# Altium schematic sheet Power_Section.SchDoc
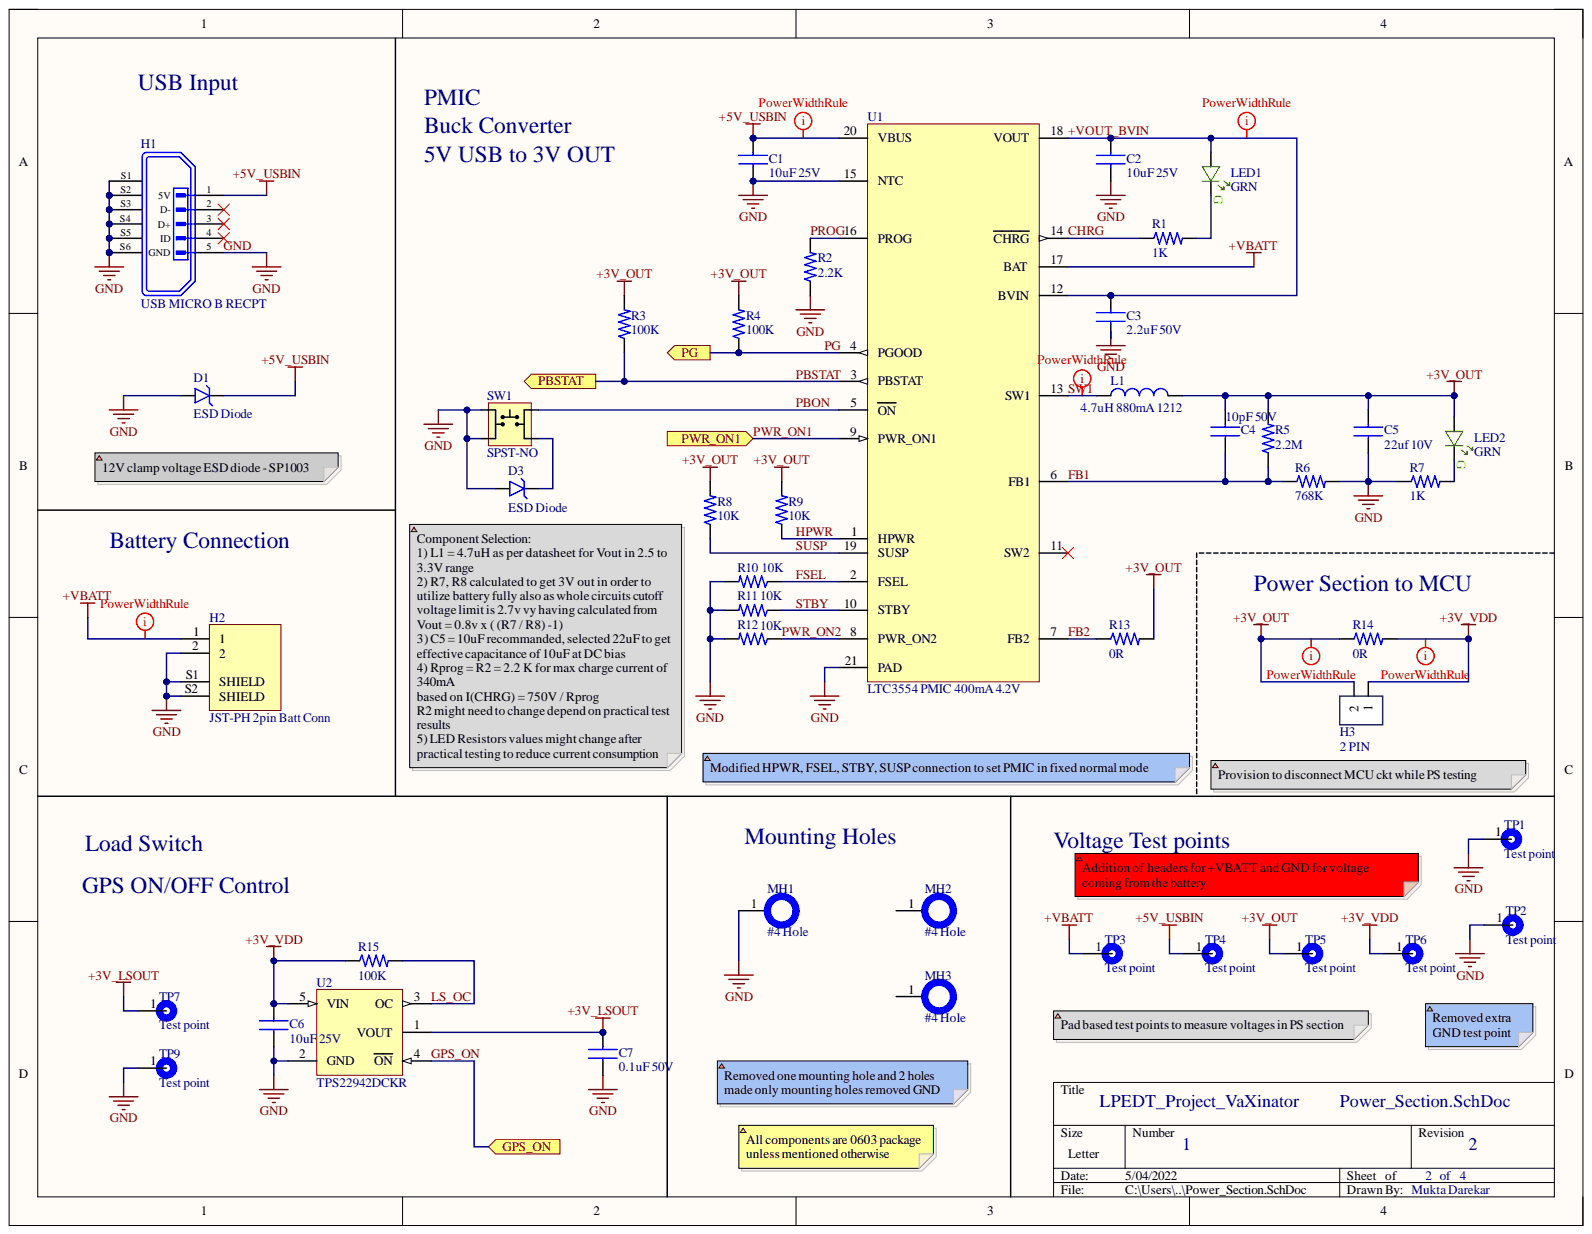
[diagram p0: Power_Section.SchDoc]

## Parts
C1  10uF 25V  [CAP_0603_1608X09N]
C2  10uF 25V  [CAP_0603_1608X09N]
C3  2.2uF 50V  Capacitance: 2.2uF  [CAP_0603_1608X09N]
C4  10pF 50V  [CAP_0603_1608X09N]
C5  22uf 10V  [CAP_0603_1608X09N]
C6  10uF 25V  [CAP_0603_1608X09N]
C7  0.1uF 50V  [CAP_0603_1608X09N]
D1  ESD Diode  [SOD882_DIODE_0402]
D3  ESD Diode  [SOD882_DIODE_0402]
H1  USB MICRO B RECPT  [MICROUSB_AMPHENOL_10118194-0001LF]
H2  JST-PH 2pin Batt Conn  [JST_S2B-PH-SM4-TB(LF)(SN)]
H3  2 PIN  [2_PIN_100MIL]
L1  4.7uH 880mA 1212  Current Rating: 880mA  Inductance: 4.7uH  [IND_CDRH2D11/HPNP-100NC]
LED1  GRN  [LED_0603_GRN_WL-SMCW]
LED2  GRN  [LED_0603_GRN_WL-SMCW]
MH1  #4 Hole  [HOLE_#4]  (no BOM)
MH2  #4 Hole  [HOLE_#4]  (no BOM)
MH3  #4 Hole  [HOLE_#4]  (no BOM)
R1  1K  [RES_0603_1608X06N]
R2  2.2K  [RES_0603_1608X06N]
R3  100K  [RES_0603_1608X06N]
R4  100K  [RES_0603_1608X06N]
R5  2.2M  Voltage Rating: 50V  [RES_0603_1608X06N]
R6  768K  [RES_0603_1608X06N]
R7  1K  [RES_0603_1608X06N]
R8  10K  [RES_0603_1608X06N]
R9  10K  [RES_0603_1608X06N]
R10  10K  [RES_0603_1608X06N]
R11  10K  [RES_0603_1608X06N]
R12  10K  [RES_0603_1608X06N]
R13  0R  [RES_0603_1608X06N]
R14  0R  [RES_0603_1608X06N]
R15  100K  [RES_0603_1608X06N]
SW1  SPST-NO  [TACT_SW_4PIN_SPST_450X450]
TP1  Test point  [TEST_POINT]  (no BOM)
TP2  Test point  [TEST_POINT]  (no BOM)
TP3  Test point  [TEST_POINT]  (no BOM)
TP4  Test point  [TEST_POINT]  (no BOM)
TP5  Test point  [TEST_POINT]  (no BOM)
TP6  Test point  [TEST_POINT]  (no BOM)
TP7  Test point  [TEST_POINT]  (no BOM)
TP9  Test point  [TEST_POINT]  (no BOM)
U1  LTC3554 PMIC 400mA 4.2V  [QFN20P300X300X80_HS-21N]
U2  TPS22942DCKR  [LS_SOT65P210X110-5N]

## Ports
GPS_ON, PBSTAT, PG, PWR_ON1

## Nets
+3V_LSOUT: C7.1 TP7.1 U2.1(VOUT)
+3V_OUT: C4.1 C5.1 H3.2 L1.2 LED2.A R3.2 R4.2 R5.2 R8.2 R9.2 R13.2 R14.1 TP5.1
+3V_VDD: C6.1 H3.1 R14.2 R15.1 TP6.1 U2.5(VIN)
+5V_USBIN: C1.1 D1.1 H1.1 TP4.1 U1.20(VBUS)
+VBATT: H2.1 TP3.1 U1.17(BAT)
+VOUT_BVIN: C2.1 C3.1 LED1.A U1.12(BVIN) U1.18(VOUT)
CHRG: R1.1 U1.14(CHRG)
FB1: C4.2 R5.1 R6.1 U1.6(FB1)
FB2: R13.1 U1.7(FB2)
FSEL: R10.1 U1.2(FSEL)
GND: C1.2 C2.2 C3.2 C5.2 C6.2 C7.2 D1.2 D3.2 H1.5 H1.S1 H1.S2(5V) H1.S3(D-) H1.S4(D+) H1.S5(ID) H1.S6(GND) H2.2 H2.S1(SHIELD) H2.S2(SHIELD) MH1.1 R2.1 R6.2 R7.1 R10.2 R11.2 R12.2 SW1.1 SW1.4 TP1.1 TP2.1 TP9.1 U1.15(NTC) U1.21(PAD) U2.2(GND)
GPS_ON: U2.4(ON) ; port GPS_ON
HPWR: R9.1 U1.1(HPWR)
LS_OC: R15.2 U2.3(OC)
PBON: SW1.2 U1.5(ON)
PBSTAT: R3.1 U1.3(PBSTAT) ; port PBSTAT
PG: R4.1 U1.4(PGOOD) ; port PG
PROG: R2.2 U1.16(PROG)
PWR_ON1: U1.9(PWR_ON1) ; port PWR_ON1
PWR_ON2: R12.1 U1.8(PWR_ON2)
STBY: R11.1 U1.10(STBY)
SUSP: R8.1 U1.19(SUSP)
SW1: L1.1 U1.13(SW1)
NetD3_1: D3.1 SW1.3
NetLED1_C: LED1.C R1.2
NetLED2_C: LED2.C R7.2

Not connected: H1 2 3 4; MH2 1; MH3 1; U1 11(SW2)

## Library data of the parts
C1 C2 C6 = GRM188R61E106KA73D: CAP CER 10UF 25V X5R 0603 MURATA; Case/Package: 0603; Category: Ceramic Capacitors; Component Kind: Standard; ComponentLink1Description: https://search.murata.co.jp/Ceramy/image/img/A01X/G101/ENG/GRM188R61E106KA73-01.pdf?src-supplier=Digi-Key; ComponentLink1URL: https://search.murata.co.jp/Ceramy/image/img/A01X/G101/ENG/GRM188R61E106KA73-01.pdf?src-supplier=Digi-Key; Library Name: LPEDT_Project_lib.IntLib; Manufacturer: Murata; Manufacturer Part Number: GRM188R61E106KA73D; Pricing 1: 1=0.32000, 10=0.22500, 50=0.15740, 100=0.13500, 500=0.10122, 1000=0.08548 (USD); RoHS: Yes; RoHS Compliant: Yes; Stock 1: 517064; Supplier 1: Digi-Key
C3 = GRM188R61H225KE11D: CAP CER 2.2 uF 50 V X5R 0603 ±10% Tolerance; Manufacturer: Murata; Material: Ceramic; Case Code (Imperial): 0603; Case Code (Metric): 1608; Case/Package: 0603; Category: Ceramic Capacitors; Component Kind: Standard; ComponentLink1Description: http://www.farnell.com/datasheets/2079169.pdf?src-supplier=Farnell; ComponentLink1URL: http://www.farnell.com/datasheets/2079169.pdf?src-supplier=Farnell; ComponentLink2Description: http://www.farnell.com/datasheets/2079169.pdf?src-supplier=Element14; ComponentLink2URL: http://www.farnell.com/datasheets/2079169.pdf?src-supplier=Element14; ComponentLink3Description: http://search.murata.co.jp/Ceramy/image/img/A01X/G101/ENG/GRM188R61H225KE11-01.pdf?src-supplier=Digi-Key; ComponentLink3URL: http://search.murata.co.jp/Ceramy/image/img/A01X/G101/ENG/GRM188R61H225KE11-01.pdf?src-supplier=Digi-Key; Dielectric: X5R; Height: 0.8mm; Length: 0.063inch; Library Name: LPEDT_Project.SchLib; Manufacturer Part Number: GRM188R61H225KE11D; Max Operating Temperature: 85°C; Min Operating Temperature: -55°C; Mount: Surface Mount; Packaging: Cut Tape; Pricing 1: 1=0.35000, 10=0.23800, 50=0.15840, 100=0.13460, 500=0.09504, 1000=0.08316 (USD); REACH SVHC: No SVHC; RoHS: Yes; RoHS Compliant: Yes; Supplier 1: Digi-Key; Thickness: 0.039inch; Tolerance: 10%; Voltage Rating: 50V; Voltage Rating (DC): 50V; Width: 0.031inch
C4 = C0603C100J5RAC7867: CAP CER 10pF 50V X7R 0603 KEMET; Category: Ceramic Capacitors; Component Kind: Standard; Library Name: LPEDT_Project.SchLib; Manufacturer: KEMET; Manufacturer Part Number: C0603C100J5RAC7867; Pricing 1: 1=0.25000, 10=0.17400, 50=0.11580, 100=0.09840, 500=0.06944, 1000=0.06075 (USD); RoHS: Yes; RoHS Compliant: Yes; Supplier 1: Digi-Key
C5 = GRM187R61A226ME15D: CAP CER 22 uF 10 V X5R 0603 ±20% Tolerance Multilayer Ceramic Capacitor; Manufacturer: Murata; Capacitance: 22uF; Case Code (Imperial): 0603; Case Code (Metric): 1608; Case/Package: 0603; Category: Ceramic Capacitors; Component Kind: Standard; ComponentLink1Description: http://search.murata.co.jp/Ceramy/image/img/A01X/G101/ENG/GRM187R61A226ME15-01.pdf?src-supplier=Digi-Key; ComponentLink1URL: http://search.murata.co.jp/Ceramy/image/img/A01X/G101/ENG/GRM187R61A226ME15-01.pdf?src-supplier=Digi-Key; Dielectric: X5R; Length: 0.063inch; Library Name: LPEDT_Project_lib.IntLib; Manufacturer Part Number: GRM187R61A226ME15D; Max Operating Temperature: 85°C; Min Operating Temperature: -55°C; Mount: Surface Mount; Packaging: Tape and Reel; Pricing 1: 1=0.52000, 10=0.36500, 50=0.27580, 100=0.24030, 500=0.17798, 1000=0.15128 (USD); RoHS: Yes; RoHS Compliant: Yes; Stock 1: 886277; Supplier 1: Digi-Key; Thickness: 0.031inch; Tolerance: 20%; Voltage Rating: 10V; Voltage Rating (DC): 10V; Width: 0.031inch
C7 = C0603C104M5RACTU: CAP CER 0.1UF 50V X7R 0603 KEMET; Capacitance: 100nF; Dielectric Material: Ceramic; Dissipation Factor: 2.5%; Tolerance: 20%; Case Code (Imperial): 0603; Case Code (Metric): 1608; Case/Package: 0603; Category: Ceramic Capacitors; Component Kind: Standard; ComponentLink1Description: http://components.arrow.com/part/dataview/40911037S8853915N7429?src-supplier=Arrow+Electronics; ComponentLink1URL: http://components.arrow.com/part/dataview/40911037S8853915N7429?src-supplier=Arrow+Electronics; ComponentLink2Description: https://www.verical.com/server-webapp/html/getDatasheet?partID=626892&src-supplier=Verical; ComponentLink2URL: https://www.verical.com/server-webapp/html/getDatasheet?partID=626892&src-supplier=Verical; ComponentLink3Description: http://www.farnell.com/datasheets/2746056.pdf?src-supplier=Farnell; ComponentLink3URL: http://www.farnell.com/datasheets/2746056.pdf?src-supplier=Farnell; ComponentLink4Description: http://components.arrow.com/part/dataview/0323_00313986?src-supplier=Arrow+Europe; ComponentLink4URL: http://components.arrow.com/part/dataview/0323_00313986?src-supplier=Arrow+Europe; ComponentLink5Description: http://www.mouser.com/ds/2/212/KEM_C1002_X7R_SMD-1102033.pdf?src-supplier=Mouser; ComponentLink5URL: http://www.mouser.com/ds/2/212/KEM_C1002_X7R_SMD-1102033.pdf?src-supplier=Mouser; ComponentLink6Description: http://www.alliedelec.com/m/d/6f3b5eb83d09db50ed28bdc730567b33.pdf?src-supplier=Allied+Electronics; ComponentLink6URL: http://www.alliedelec.com/m/d/6f3b5eb83d09db50ed28bdc730567b33.pdf?src-supplier=Allied+Electronics; ComponentLink7Description: http://www.farnell.com/datasheets/1828800.pdf?src-supplier=Newark; ComponentLink7URL: http://www.farnell.com/datasheets/1828800.pdf?src-supplier=Newark; ComponentLink8Description: http://capacitoredge.kemet.com/capedge2/DataSheet?pn=C0603C104M5RAC7867&src-supplier=Avnet; ComponentLink8URL: http://capacitoredge.kemet.com/capedge2/DataSheet?pn=C0603C104M5RAC7867&src-supplier=Avnet; ComponentLink9Description: http://www.farnell.com/datasheets/2315113.pdf?src-supplier=Newark; ComponentLink9URL: http://www.farnell.com/datasheets/2315113.pdf?src-supplier=Newark; ComponentLink10Description: https://api.kemet.com/component-edge/download/datasheet/C0603C104M5RACTU.pdf?src-supplier=Digi-Key; ComponentLink10URL: https://api.kemet.com/component-edge/download/datasheet/C0603C104M5RACTU.pdf?src-supplier=Digi-Key; ComponentLink11Description: https://www.verical.com/server-webapp/html/getDatasheet?partID=110138&src-supplier=Verical; ComponentLink11URL: https://www.verical.com/server-webapp/html/getDatasheet?partID=110138&src-supplier=Verical; ComponentLink12Description: http://www.farnell.com/datasheets/2746056.pdf?src-supplier=Element14; ComponentLink12URL: http://www.farnell.com/datasheets/2746056.pdf?src-supplier=Element14; ComponentLink13Description: http://www.farnell.com/datasheets/2746056.pdf?src-supplier=Newark; ComponentLink13URL: http://www.farnell.com/datasheets/2746056.pdf?src-supplier=Newark; ComponentLink14Description: http://capacitoredge.kemet.com/capedge2/DataSheet?pn=C0603C104M5RAC7867&src-supplier=Avnet+Asia; ComponentLink14URL: http://capacitoredge.kemet.com/capedge2/DataSheet?pn=C0603C104M5RAC7867&src-supplier=Avnet+Asia; Construction: Flat; Contact Plating: Tin,Matte; Depth: 0.8mm; Dielectric: X7R; Height: 0.8mm; Lead Pitch: 0.7mm; Length: 1.6mm; Library Name: LPEDT_Project_lib.IntLib; Manufacturer: KEMET; Manufacturer Part Number: C0603C104M5RACTU; Max Operating Temperature: 125°C; Min Operating Temperature: -55°C; Mount: Surface Mount,PCB; Package Quantity: 1; Packaging: Tape and Reel; Pricing 1: 1=0.10000, 10=0.03400, 50=0.01840, 100=0.01510, 500=0.01078, 1000=0.00847 (USD); Radiation Hardening: No; REACH SVHC: No SVHC; RoHS: Yes; Series: C; Supplier 1: Digi-Key; Termination: SMD/SMT; Thickness: 0.034inch; Voltage Rating: 50V; Voltage Rating (DC): 50V; Width: 0.031inch
D1 D3 = SP1003-01ETG: TVS DIODE 5VWM 12VC SOD882; Manufacturer: Littelfuse; Breakdown Voltage: 5V; Capacitance: 30pF; Clamping Voltage: 12V; Diode Type: ZENER; Leakage Current: 100nA; Max Surge Current: 7A; Min Breakdown Voltage: 6V; Number of Channels: 1; Polarity: Bidirectional,Unidirectional; Power Line Protection: No; Test Current: 1mA; Category: TVS Diodes; Component Kind: Standard; ComponentLink1Description: https://datasheet.ciiva.com/4507/littelfuse-tvs-diode-array-spa-sp1003-221268-4507734.pdf?src-supplier=Mouser; ComponentLink1URL: https://datasheet.ciiva.com/4507/littelfuse-tvs-diode-array-spa-sp1003-221268-4507734.pdf?src-supplier=Mouser; ComponentLink2Description: https://datasheet.ciiva.com/16488/littelfuse-tvs-diode-array-spa-sp1003-16488471.pdf?src-supplier=Future+Electronics; ComponentLink2URL: https://datasheet.ciiva.com/16488/littelfuse-tvs-diode-array-spa-sp1003-16488471.pdf?src-supplier=Future+Electronics; ComponentLink3Description: https://datasheet.ciiva.com/1268/1854133-1268499.pdf?src-supplier=Element14; ComponentLink3URL: https://datasheet.ciiva.com/1268/1854133-1268499.pdf?src-supplier=Element14; ComponentLink4Description: https://datasheet.ciiva.com/330/littelfuse-tvs-diode-array-sp1003-datasheet-pdf-330595.pdf?src-supplier=Digi-Key; ComponentLink4URL: https://datasheet.ciiva.com/330/littelfuse-tvs-diode-array-sp1003-datasheet-pdf-330595.pdf?src-supplier=Digi-Key; ComponentLink5Description: https://datasheet.ciiva.com/330/littelfuse-tvs-diode-array-sp1003-datasheet-pdf-330596.pdf?src-supplier=Digi-Key; ComponentLink5URL: https://datasheet.ciiva.com/330/littelfuse-tvs-diode-array-sp1003-datasheet-pdf-330596.pdf?src-supplier=Digi-Key; ComponentLink6Description: https://datasheet.ciiva.com/330/littelfuse-tvs-diode-array-sp1003-datasheet-pdf-330594.pdf?src-supplier=Digi-Key; ComponentLink6URL: https://datasheet.ciiva.com/330/littelfuse-tvs-diode-array-sp1003-datasheet-pdf-330594.pdf?src-supplier=Digi-Key; Lead Free: Lead Free; Library Name: LPEDT_Project_lib.IntLib; Manufacturer Part Number: SP1003-01ETG; Max Operating Temperature: 85°C; Min Operating Temperature: -40°C; Mount: Surface Mount; Number of Elements: 1; Number of Pins: 2; Number of Unidirectional Channels: 1; Operating Supply Voltage: 5V; Package Quantity: 3000; Packaging: Tape and Reel; Peak Pulse Current: 7A; Pricing 1: 1=0.47000, 10=0.38000, 100=0.25870, 500=0.19404, 1000=0.14553, 2000=0.13340, 5000=0.12532 (USD); REACH SVHC: No SVHC; Resistor Type: Zener; Reverse Breakdown Voltage: 6V; Reverse Standoff Voltage: 5V; RoHS: Yes; RoHS Compliant: Yes; Series: Automotive, AEC-Q101, SP1003, SPA®; Stock 1: 77390; Supplier 1: Digi-Key; Termination: SMD/SMT
H1 = 10118194-0001LF: USB - micro B USB 2.0 Receptacle Connector 5 Position Surface Mount, Right Angle; Through Hole; Manufacturer: Amphenol ICC / FCI; Category: USB Connectors; Component Kind: Standard; ComponentLink1Description: http://components.arrow.com/part/dataview/0323_04107373?src-supplier=Arrow+Europe; ComponentLink1URL: http://components.arrow.com/part/dataview/0323_04107373?src-supplier=Arrow+Europe; ComponentLink2Description: https://cdn.amphenol-icc.com/media/wysiwyg/files/drawing/10118194.pdf?src-supplier=Avnet; ComponentLink2URL: https://cdn.amphenol-icc.com/media/wysiwyg/files/drawing/10118194.pdf?src-supplier=Avnet; ComponentLink3Description: http://www.farnell.com/datasheets/2366786.pdf?src-supplier=Newark; ComponentLink3URL: http://www.farnell.com/datasheets/2366786.pdf?src-supplier=Newark; ComponentLink4Description: https://cdn.amphenol-icc.com/media/wysiwyg/files/drawing/10118194.pdf?src-supplier=Mouser; ComponentLink4URL: https://cdn.amphenol-icc.com/media/wysiwyg/files/drawing/10118194.pdf?src-supplier=Mouser; ComponentLink5Description: http://www.amphenol-icc.com/media/wysiwyg/files/drawing/10118194.pdf?src-supplier=Digi-Key; ComponentLink5URL: http://www.amphenol-icc.com/media/wysiwyg/files/drawing/10118194.pdf?src-supplier=Digi-Key; ComponentLink6Description: http://www.farnell.com/cad/2354948.pdf?src-supplier=Farnell; ComponentLink6URL: http://www.farnell.com/cad/2354948.pdf?src-supplier=Farnell; ComponentLink7Description: https://cdn.amphenol-icc.com/media/wysiwyg/files/drawing/10118194.pdf?src-supplier=RSComponents; ComponentLink7URL: https://cdn.amphenol-icc.com/media/wysiwyg/files/drawing/10118194.pdf?src-supplier=RSComponents; ComponentLink8Description: https://cdn.amphenol-icc.com/media/wysiwyg/files/drawing/10118194.pdf?src-supplier=Allied+Electronics; ComponentLink8URL: https://cdn.amphenol-icc.com/media/wysiwyg/files/drawing/10118194.pdf?src-supplier=Allied+Electronics; ComponentLink9Description: https://cdn.amphenol-icc.com/media/wysiwyg/files/drawing/10118194.pdf?src-supplier=Verical; ComponentLink9URL: https://cdn.amphenol-icc.com/media/wysiwyg/files/drawing/10118194.pdf?src-supplier=Verical; ComponentLink10Description: https://cdn.amphenol-icc.com/media/wysiwyg/files/drawing/10118194.pdf?src-supplier=Arrow+Electronics; ComponentLink10URL: https://cdn.amphenol-icc.com/media/wysiwyg/files/drawing/10118194.pdf?src-supplier=Arrow+Electronics; ComponentLink11Description: https://cdn.amphenol-icc.com/media/wysiwyg/files/drawing/10118194.pdf?src-supplier=TTI; ComponentLink11URL: https://cdn.amphenol-icc.com/media/wysiwyg/files/drawing/10118194.pdf?src-supplier=TTI; ComponentLink12Description: https://cdn.amphenol-icc.com/media/wysiwyg/files/drawing/10118194.pdf?src-supplier=NAC+Semi; ComponentLink12URL: https://cdn.amphenol-icc.com/media/wysiwyg/files/drawing/10118194.pdf?src-supplier=NAC+Semi; ComponentLink13Description: https://cdn.amphenol-icc.com/media/wysiwyg/files/drawing/10118194.pdf?src-supplier=SOS+electronic; ComponentLink13URL: https://cdn.amphenol-icc.com/media/wysiwyg/files/drawing/10118194.pdf?src-supplier=SOS+electronic; ComponentLink14Description: https://cdn.amphenol-icc.com/media/wysiwyg/files/drawing/10118194.pdf?src-supplier=Quest; ComponentLink14URL: https://cdn.amphenol-icc.com/media/wysiwyg/files/drawing/10118194.pdf?src-supplier=Quest; ComponentLink15Description: https://cdn.amphenol-icc.com/media/wysiwyg/files/drawing/10118194.pdf?src-supplier=Heilind+Electronics; ComponentLink15URL: https://cdn.amphenol-icc.com/media/wysiwyg/files/drawing/10118194.pdf?src-supplier=Heilind+Electronics; ComponentLink16Description: https://cdn.amphenol-icc.com/media/wysiwyg/files/drawing/10118194.pdf?src-supplier=TME; ComponentLink16URL: https://cdn.amphenol-icc.com/media/wysiwyg/files/drawing/10118194.pdf?src-supplier=TME; Library Name: LPEDT_Project_lib.IntLib; Manufacturer Part Number: 10118194-0001LF; RoHS: No; Supplier 1: Digi-Key
H2 = JST-PH-1769: CML 1769 LMP T-1 3/4 INC Midget Screw .2A 2.5V Part of the VCC Family of Products; Manufacturer: Adafruit Industries; Manufacturer Part Number: 1769; Category: Headers and Wire Housings; Component Kind: Standard; Library Name: LPEDT_Project_lib.IntLib; Pricing 1: 1=0.75000, 10=0.75000, 50=0.75000, 100=0.75000, 1000=0.75000, 10000=0.75000 (USD); RoHS: No; Stock 1: 8734; Supplier 1: Mouser
H3 = J_2_PIN_HEADER: 2.54mm Straight 2 1 Header，Breakaway Through Hole,P=2.54mm Pin Header & Female Header ROHS; MFR Part #: MTP125-1102S1; Component Kind: Standard; Datasheetxx: https://datasheet.lcsc.com/lcsc/1912111437_MINTRON-MTP125-1102S1_C358684.pdf; LCSC Part#: C358684; Library Name: LPEDT_Project_lib.IntLib; Type: Extended; Value: 2 PIN
L1 = CDRH2D11NP-4R7NC: Ind Power Shielded Wirewound 4.7uH 30% 100KHz 880mA 1212 Carrier T/R; Manufacturer: Sumida; Core Material: Ferrite; DC Resistance (DCR): 170mR; Rated Current-Max: 500mA; Case/Package: Nonstandard; Category: Fixed Inductors; Component Kind: Standard; ComponentLink1Description: http://www.mouser.com/ds/2/390/CDRH2D11-523438.pdf?src-supplier=Mouser; ComponentLink1URL: http://www.mouser.com/ds/2/390/CDRH2D11-523438.pdf?src-supplier=Mouser; ComponentLink2Description: http://www.sumida.com/products/pdf/CDRH2D11,2D11HP,2D11B.pdf?src-supplier=Avnet; ComponentLink2URL: http://www.sumida.com/products/pdf/CDRH2D11,2D11HP,2D11B.pdf?src-supplier=Avnet; ComponentLink3Description: http://products.sumida.com/products/pdf/CDRH2D11.pdf?src-supplier=Digi-Key; ComponentLink3URL: http://products.sumida.com/products/pdf/CDRH2D11.pdf?src-supplier=Digi-Key; ComponentLink4Description: http://www.sumida.com/products/pdf/CDRH2D11_HP_,2D11B_HP_.pdf?src-supplier=Future+Electronics; ComponentLink4URL: http://www.sumida.com/products/pdf/CDRH2D11_HP_,2D11B_HP_.pdf?src-supplier=Future+Electronics; Depth: 3.2mm; Height: 1.2mm; Height - Seated (Max): 0.043inch; Lead Free: Lead Free; Length: 0.118inch; Library Name: LPEDT_Project.SchLib; Manufacturer Part Number: CDRH2D11NP-4R7NC; Max DC Current: 880mA; Max Operating Temperature: 100°C; Min Operating Temperature: -40°C; Mount: Surface Mount; Package Quantity: 1; Packaging: Tape and Reel; Radiation Hardening: No; RoHS: Yes; RoHS Compliant: Yes; Saturation Current: 500mA; Series: CDRH; Shielding: Shielded; Supplier 1: Digi-Key; Termination: SMD/SMT; Test Frequency: 100kHz; Tolerance: 30%; Width: 0.118inch
LED1 LED2 = 150060GS75000: LED, 0603, GREEN, 430MCD, 520NM; Manufacturer: Wurth Electronics; 3D Model: SMCW_0603.igs    SMCW_0603.stp    SMCW_0603.wrl; Current - Test: 20mA; Dynamic Catalog: 0603 Rectangle with Flat Top Chip LEDs; Lens Style/Size: Rectangle with Flat Top, 1.1mm x 0.7mm; Lens Type: Clear; Other Names: 732-4971-2; Viewing Angle: 140°; Voltage - Forward (Vf) (Typ): 3.2V; Wavelength - Peak: 515nm; Category: Optoelectronics; Color: Green; Component Kind: Standard; ComponentLink1Description: https://katalog.we-online.de/led/datasheet/150060GS75000.pdf?src-supplier=Digi-Key; ComponentLink1URL: https://katalog.we-online.de/led/datasheet/150060GS75000.pdf?src-supplier=Digi-Key; ComponentLink2Description: http://www.produktinfo.conrad.com/datenblaetter/750000-774999/759427-da-01-en-CHIPLED_0603_W_KL_GRUEN_150060GS75000.pdf?src-supplier=Conrad; ComponentLink2URL: http://www.produktinfo.conrad.com/datenblaetter/750000-774999/759427-da-01-en-CHIPLED_0603_W_KL_GRUEN_150060GS75000.pdf?src-supplier=Conrad; Family: LED Indication - Discrete; Height: 0.70mm; Library Name: LPEDT_Project.SchLib; Manufacturer Part Number: 150060GS75000; Millicandela Rating: 430mcd; Mounting Type: Surface Mount; Online Catalog: 0603 Rectangle with Flat Top Chip LEDs; Package / Case: 0603 (1608 Metric); Packaging: Tape & Reel (TR); Pricing 1: 1=0.14, 50=0.129, 100=0.11, 500=0.095 (USD); Series: WL-SMCW; Size / Dimension: 1.60mm L x 0.80mm W; Standard Package: 4,000; Stock 1: 34964; Supplier 1: Digi-Key; Supplier Part Number 1: 732-4971-1-ND; Wavelength - Dominant: 520nm
MH1-MH3 = HOLE_#4: Mounting Hole; Library Name: LPEDT_Project_lib.IntLib
R1 R7 = RC0603FR-071KL: RES 1KΩ ± 1.00% 0.1W ± 200ppm/℃ 0603; Manufacturer: Yageo; Max Power Dissipation: 100mW; Resistance: 1kR; Resistance Tolerance: 1%; Temperature Coefficient: 100ppm/°C; Voltage Rating: 50V; Case Code (Imperial): 0603; Case Code (Metric): 1608; Case/Package: 0603; Category: Chip SMD Resistors; Component Kind: Standard; ComponentLink1Description: https://datasheet.ciiva.com/7746/1686128-7746280.pdf?src-supplier=Element14; ComponentLink1URL: https://datasheet.ciiva.com/7746/1686128-7746280.pdf?src-supplier=Element14; ComponentLink2Description: https://datasheet.ciiva.com/7660/1868787-7660790.pdf?src-supplier=Element14; ComponentLink2URL: https://datasheet.ciiva.com/7660/1868787-7660790.pdf?src-supplier=Element14; Construction: Rectangular; Contact Plating: Tin,Matte; Depth: 0.8mm; Features: Moisture Resistant; Height: 0.45mm; Lead Free: Lead Free; Length: 1.6mm; Manufacturer Part Number: RC0603FR-071KL; Max Operating Temperature: 155°C; Military Standard: Not; Min Operating Temperature: -55°C; Number of Terminations: 2; Packaging: Tape and Reel; Power Rating: 100mW; Pricing 1: 5000=0.00312 (USD); Radiation Hardening: No; REACH SVHC: No SVHC; Resistor Type: Thick Film; RoHS: Yes; RoHS Compliant: Yes; Series: RC; Size Code: 0603; Stock 1: 9007788; Supplier 1: Digi-Key; Technology: THICK FILM; Termination: SMD/SMT; Tolerance: 1%
  R1: Library Name: LPEDT_Project_lib.IntLib
  R7: Library Name: LPEDT_Project.SchLib
R2 = RC0603FR-132K2L: Res Thick Film 0603 2.2K Ohm 1% 0.1W(1/10W) ±100ppm/C Pad SMD T/R; Manufacturer: Yageo; Power Rating: 100mW; Resistance: 2.2kR; Temperature Coefficient: 100ppm/°C; Voltage Rating: 50V; Case Code (Imperial): 0603; Case Code (Metric): 1608; Case/Package: 0603; Category: Chip SMD Resistors; Component Kind: Standard; ComponentLink1Description: https://datasheet.ciiva.com/12561/rc0603-272842-12561118.pdf?src-supplier=Mouser; ComponentLink1URL: https://datasheet.ciiva.com/12561/rc0603-272842-12561118.pdf?src-supplier=Mouser; Construction: Rectangular; Depth: 0.8mm; Height: 0.45mm; Lead Free: Lead Free; Length: 1.6mm; Library Name: LPEDT_Project_lib.IntLib; Manufacturer Part Number: RC0603FR-132K2L; Max Operating Temperature: 155°C; Military Standard: Not; Min Operating Temperature: -55°C; Packaging: Tape and Reel; Pricing 1: 1=0.10000, 10=0.02400, 100=0.00970, 1000=0.00436, 2500=0.00378, 5000=0.00312 (USD); Resistor Type: Thick Film; RoHS: Yes; RoHS Compliant: Yes; Series: RC; Size Code: 0603; Stock 1: 18388; Supplier 1: Digi-Key; Termination: SMD/SMT; Tolerance: 1%; Width: 0.8mm
R3 R4 R15 = RC0603FR-10100KL: Res Thick Film 0603 100K Ohm 1% 0.1W(1/10W) ±100ppm/C Pad SMD T/R; Manufacturer: Yageo; Power Rating: 100mW; Resistance: 100kR; Temperature Coefficient: 100ppm/°C; Voltage Rating: 50V; Case Code (Imperial): 0603; Case Code (Metric): 1608; Case/Package: 0603; Category: Chip SMD Resistors; Component Kind: Standard; ComponentLink1Description: https://datasheet.ciiva.com/7983/443971-7983653.pdf?src-supplier=Element14; ComponentLink1URL: https://datasheet.ciiva.com/7983/443971-7983653.pdf?src-supplier=Element14; Construction: Rectangular; Contact Plating: Tin,Lead; Depth: 0.8mm; Height: 0.45mm; Length: 1.6mm; Library Name: LPEDT_Project_lib.IntLib; Manufacturer Part Number: RC0603FR-10100KL; Max Operating Temperature: 155°C; Military Standard: Not; Min Operating Temperature: -55°C; Number of Pins: 2; Packaging: Tape and Reel; Pricing 1: 1=0.10000, 10=0.02400, 100=0.00970, 1000=0.00436, 2500=0.00378, 5000=0.00312 (USD); REACH SVHC: Unknown; Resistance Tolerance: 1%; Resistor Type: Thick Film; RoHS: Yes; RoHS Compliant: Yes; Series: RC; Stock 1: 302; Supplier 1: Digi-Key; Termination: SMD/SMT; Tolerance: 1%; Voltage Rating (DC): 50V; Width: 0.8mm
R5 = RC0603FR-132M2L: Res Thick Film 0603 2.2M Ohm 1% 1/10W ±100ppm/°C Molded SMD SMD Paper T/R; Manufacturer: Yageo; Power Rating: 100mW; Resistance: 2.2MR; Case Code (Imperial): 0603; Case Code (Metric): 1608; Case/Package: 0603; Category: Chip SMD Resistors; Component Kind: Standard; ComponentLink1Description: http://www.mouser.com/ds/2/447/RC0603-272842.pdf?src-supplier=Mouser; ComponentLink1URL: http://www.mouser.com/ds/2/447/RC0603-272842.pdf?src-supplier=Mouser; ComponentLink2Description: http://www.yageo.com/documents/recent/PYu-RC_Group_51_RoHS_L_2.pdf?src-supplier=Avnet; ComponentLink2URL: http://www.yageo.com/documents/recent/PYu-RC_Group_51_RoHS_L_2.pdf?src-supplier=Avnet; ComponentLink3Description: http://www.yageo.com/documents/recent/PYu-RC0603_51_RoHS_L_5.pdf?src-supplier=Avnet+Europe; ComponentLink3URL: http://www.yageo.com/documents/recent/PYu-RC0603_51_RoHS_L_5.pdf?src-supplier=Avnet+Europe; Contact Plating: Tin,Matte; Height: 0.45mm; Length: 1.6mm; Library Name: LPEDT_Project_lib.IntLib; Manufacturer Part Number: RC0603FR-132M2L; Max Operating Temperature: 155°C; Min Operating Temperature: -55°C; Packaging: Tape and Reel; Pricing 1: 1=0.10000, 10=0.02400, 100=0.00970, 1000=0.00436, 2500=0.00378, 5000=0.00312 (USD); Radiation Hardening: No; Resistor Type: Thick Film; RoHS: Yes; RoHS Compliant: Yes; Series: RC; Stock 1: 39650; Supplier 1: Digi-Key; Temperature Coefficient: 100ppm/°C; Termination: SMD/SMT; Tolerance: 1%; Width: 0.8mm
R6 = RC0603FR-07768KL: Res Thick Flim 0603 768KΩ 1% 1/10W ±100ppm/°C Molded SMD; Manufacturer: Yageo; Max Power Dissipation: 100mW; Resistance: 768kR; Voltage Rating: 50V; Case Code (Imperial): 0603; Case Code (Metric): 1608; Case/Package: 0603; Category: Chip SMD Resistors; Component Kind: Standard; ComponentLink1Description: https://datasheet.ciiva.com/2454/pyu-r-int-thick-7-2454457.pdf?src-supplier=Digi-Key; ComponentLink1URL: https://datasheet.ciiva.com/2454/pyu-r-int-thick-7-2454457.pdf?src-supplier=Digi-Key; ComponentLink2Description: https://datasheet.ciiva.com/2454/pyu-r-int-thick-7-2454458.pdf?src-supplier=Digi-Key; ComponentLink2URL: https://datasheet.ciiva.com/2454/pyu-r-int-thick-7-2454458.pdf?src-supplier=Digi-Key; ComponentLink3Description: https://datasheet.ciiva.com/2454/pyu-r-int-thick-7-2454456.pdf?src-supplier=Digi-Key; ComponentLink3URL: https://datasheet.ciiva.com/2454/pyu-r-int-thick-7-2454456.pdf?src-supplier=Digi-Key; ComponentLink4Description: https://datasheet.ciiva.com/5596/rc0603-272842-5596007.pdf?src-supplier=Mouser; ComponentLink4URL: https://datasheet.ciiva.com/5596/rc0603-272842-5596007.pdf?src-supplier=Mouser; Construction: Rectangular; Depth: 0.8mm; Features: Moisture Resistant; Height: 0.022inch; Lead Free: Lead Free; Length: 1.6mm; Library Name: LPEDT_Project_lib.IntLib; Manufacturer Part Number: RC0603FR-07768KL; Max Operating Temperature: 155°C; Military Standard: Not; Min Operating Temperature: -55°C; Number of Terminations: 2; Package Quantity: 5000; Packaging: Tape and Reel; Power Rating: 100mW; Pricing 1: 1=0.10000, 10=0.02400, 100=0.00970, 1000=0.00436, 2500=0.00378 (USD); Resistor Type: Thick Film; RoHS: Yes; RoHS Compliant: Yes; Series: RC; Stock 1: 16330; Supplier 1: Digi-Key; Temperature Coefficient: 100ppm/°C; Termination: SMD/SMT; Tolerance: 1%; Voltage Rating (DC): 50V; Width: 0.8mm
R8-R12 = RC0603FR-0710KL: Res Thick Film 0603 10K Ohm 1% 1/10W ±100ppm/°C; Manufacturer: Yageo; Max Power Dissipation: 100mW; Resistance: 10kR; Voltage Rating: 75V; Voltage Rating (DC): 50V; Case Code (Imperial): 0603; Case Code (Metric): 1608; Case/Package: 0603; Category: Chip SMD Resistors; Component Kind: Standard; ComponentLink1Description: https://datasheet.ciiva.com/7660/38590-7660789.pdf?src-supplier=Element14; ComponentLink1URL: https://datasheet.ciiva.com/7660/38590-7660789.pdf?src-supplier=Element14; ComponentLink2Description: https://datasheet.ciiva.com/7983/443971-7983596.pdf?src-supplier=Element14; ComponentLink2URL: https://datasheet.ciiva.com/7983/443971-7983596.pdf?src-supplier=Element14; ComponentLink3Description: https://datasheet.ciiva.com/22747/443971-22747519.pdf?src-supplier=Element14; ComponentLink3URL: https://datasheet.ciiva.com/22747/443971-22747519.pdf?src-supplier=Element14; ComponentLink4Description: https://datasheet.ciiva.com/46440/1868787-46440891.pdf?src-supplier=Element14; ComponentLink4URL: https://datasheet.ciiva.com/46440/1868787-46440891.pdf?src-supplier=Element14; ComponentLink5Description: https://datasheet.ciiva.com/7746/1738695-7746239.pdf?src-supplier=Element14; ComponentLink5URL: https://datasheet.ciiva.com/7746/1738695-7746239.pdf?src-supplier=Element14; ComponentLink6Description: https://datasheet.ciiva.com/15189/getdatasheetpartid-409289-15189591.pdf?src-supplier=Verical; ComponentLink6URL: https://datasheet.ciiva.com/15189/getdatasheetpartid-409289-15189591.pdf?src-supplier=Verical; Construction: Rectangular; Depth: 0.8mm; Features: Moisture Resistant; Height: 0.45mm; Lead Free: Lead Free; Lead Pitch: 0.3mm; Length: 1.6mm; Library Name: LPEDT_Project_lib.IntLib; Manufacturer Part Number: RC0603FR-0710KL; Max Operating Temperature: 155°C; Military Standard: Not; Min Operating Temperature: -55°C; Number of Pins: 2; Number of Terminations: 2; Packaging: Tape and Reel; Power Rating: 100mW; Pricing 1: 1=0.10000, 10=0.02400, 100=0.00970, 1000=0.00436, 2500=0.00378 (USD); REACH SVHC: Unknown; Resistance Tolerance: 1%; Resistor Type: Thick Film; RoHS: Yes; RoHS Compliant: Yes; Series: RC; Size Code: 0603; Supplier 1: Digi-Key; Temperature Coefficient: 100ppm/°C; Termination: SMD/SMT; Tolerance: 1%; Weight: 7.1E-05oz; Width: 0.8mm
R13 R14 = RC0603FR-070RL: Res Thick Film 0603 0 OHM JUMPER 1/10W 0603 0Ω ± 1.00% 0.1W 0603; Manufacturer: Yageo; Current Rating: 1A; Max Power Dissipation: 100mW; Resistance: 0mR; Resistance Tolerance: 1%; Temperature Coefficient: 200ppm/°C; Voltage Rating: 50V; Working Voltage: 75V; Case Code (Imperial): 0603; Case Code (Metric): 1608; Case/Package: 0603; Category: Chip SMD Resistors; Component Kind: Standard; ComponentLink1Description: https://datasheet.ciiva.com/11808/0900766b80f96399-11808172.pdf?src-supplier=RSComponents; ComponentLink1URL: https://datasheet.ciiva.com/11808/0900766b80f96399-11808172.pdf?src-supplier=RSComponents; ComponentLink2Description: https://datasheet.ciiva.com/5684/443971-5684699.pdf?src-supplier=Farnell; ComponentLink2URL: https://datasheet.ciiva.com/5684/443971-5684699.pdf?src-supplier=Farnell; ComponentLink3Description: https://datasheet.ciiva.com/7983/443971-7983627.pdf?src-supplier=Element14; ComponentLink3URL: https://datasheet.ciiva.com/7983/443971-7983627.pdf?src-supplier=Element14; ComponentLink4Description: https://datasheet.ciiva.com/22643/1868787-22643388.pdf?src-supplier=Element14; ComponentLink4URL: https://datasheet.ciiva.com/22643/1868787-22643388.pdf?src-supplier=Element14; ComponentLink5Description: https://datasheet.ciiva.com/46382/443971-46382072.pdf?src-supplier=Element14; ComponentLink5URL: https://datasheet.ciiva.com/46382/443971-46382072.pdf?src-supplier=Element14; ComponentLink6Description: https://datasheet.ciiva.com/29721/pyu-r-int-thick-7-29721178.pdf?src-supplier=Digi-Key; ComponentLink6URL: https://datasheet.ciiva.com/29721/pyu-r-int-thick-7-29721178.pdf?src-supplier=Digi-Key; ComponentLink7Description: https://datasheet.ciiva.com/29721/pyu-rc0603-51-rohs-l-4-29721179.pdf?src-supplier=Digi-Key; ComponentLink7URL: https://datasheet.ciiva.com/29721/pyu-rc0603-51-rohs-l-4-29721179.pdf?src-supplier=Digi-Key; ComponentLink8Description: https://datasheet.ciiva.com/5558/rc0603-272842-5558341.pdf?src-supplier=Mouser; ComponentLink8URL: https://datasheet.ciiva.com/5558/rc0603-272842-5558341.pdf?src-supplier=Mouser; Construction: Rectangular; Contact Plating: Tin,Matte; Depth: 0.8mm; Features: Moisture Resistant; Height: 0.45mm; Lead Free: Lead Free; Length: 1.6mm; Library Name: LPEDT_Project_lib.IntLib; Manufacturer Part Number: RC0603FR-070RL; Max Operating Temperature: 155°C; Military Standard: Not; Min Operating Temperature: -55°C; Number of Pins: 2; Number of Terminations: 2; Package Quantity: 5000; Packaging: Tape and Reel; Power Rating: 100mW; Pricing 1: 1=0.10000, 10=0.01800, 25=0.01280, 50=0.00980, 100=0.00730, 250=0.00552, 500=0.00442, 1000=0.00326 (USD); Radiation Hardening: No; REACH SVHC: No SVHC; Resistor Type: Thick Film; RoHS: Yes; RoHS Compliant: Yes; Series: RC; Stock 1: 133608; Supplier 1: Digi-Key; Technology: THICK FILM; Termination: SMD/SMT; Tolerance: 1%; Voltage Rating (DC): 50V; Width: 0.031inch
SW1 = TL3305AF160QG: TL3305A Series SPST 12 V 4.5 x 4.5 mm 160 gf Top Actuated SMT Tactile Switch; Manufacturer: E-Switch; Actuator Type: Standard; Illumination Type: Non-Illuminated; Mechanical Life: 500000Cycles; Operating Force: 160gf; Switch Function: Off-Mom; Switch Travel: 0.2mm; Category: Tactile Switches; Component Kind: Standard; ComponentLink1Description: http://www.farnell.com/datasheets/2554191.pdf?src-supplier=Farnell; ComponentLink1URL: http://www.farnell.com/datasheets/2554191.pdf?src-supplier=Farnell; ComponentLink2Description: http://spec_sheets.e-switch.com/specs/P010468.pdf?src-supplier=Digi-Key; ComponentLink2URL: http://spec_sheets.e-switch.com/specs/P010468.pdf?src-supplier=Digi-Key; ComponentLink3Description: http://www.farnell.com/datasheets/2554191.pdf?src-supplier=Newark; ComponentLink3URL: http://www.farnell.com/datasheets/2554191.pdf?src-supplier=Newark; Lead Free: Lead Free; Library Name: LPEDT_Project_lib.IntLib; Manufacturer Part Number: TL3305AF160QG; Max Operating Temperature: 70°C; Min Operating Temperature: -20°C; Mount: Surface Mount; Packaging: Tape and Reel; Pricing 1: 1=0.21000, 10=0.20500, 25=0.19440, 100=0.17600, 250=0.16048, 500=0.14918, 1000=0.12726 (USD); RoHS: Yes; RoHS Compliant: Yes; Stock 1: 31081; Supplier 1: Digi-Key; Termination: Gull Wing; Throw Configuration: SPST
TP1-TP7 TP9 = TEST_POINT: Test point - Top layer 1.5mm round Pad; Library Name: LPEDT_Project.SchLib
U1 = LTC3554EUD-2#PBF: PMIC Switching Battery Charger Li-Ion/Li-Pol 400mA 4.2V 20-Pin QFN EP Tube; Manufacturer: Analog Devices / Linear Technology; Max Input Voltage: 5.5V; Min Input Voltage: 4.35V; Number of Cells: 1; Number of Outputs: 2; Operating Supply Current: 10uA; Case/Package: QFN; Category: Battery Management; Component Kind: Standard; ComponentLink1Description: https://datasheet.ciiva.com/1964/27687-1964824.pdf?src-supplier=Digi-Key; ComponentLink1URL: https://datasheet.ciiva.com/1964/27687-1964824.pdf?src-supplier=Digi-Key; Library Name: LPEDT_Project.SchLib; Manufacturer Part Number: LTC3554EUD-2#PBF; Max Operating Temperature: 85°C; Min Operating Temperature: -40°C; Number of Pins: 20; Package Quantity: 121; Packaging: Rail/Tube; Pricing 1: 1=6.36000, 10=5.74300, 25=5.47600, 100=4.75470, 250=4.54104, 500=4.15800 (USD); RoHS: Yes; RoHS Compliant: Yes; Series: PowerPath™; Stock 1: 121; Supplier 1: Digi-Key
U2 = TPS22942DCKR: 5.5-V, 0.1-A, 500-mΩ, load switch with current limit and active low enable 5-SC70 -40 to 85; Manufacturer: Texas Instruments; Fault Protection: Over Temperature; Interface: On/Off; Max Input Voltage: 5.5V; Max Output Current: 100mA; Max Power Dissipation: 1.22mW; Max Supply Current: 80uA; Min Input Voltage: 1.62V; Nominal Supply Current: 1uA; Number of Channels: 1; On-State Resistance: 500mR; Output Configuration: High Side; Output Current: 200mA; Quiescent Current: 40uA; Turn-Off Delay Time: 30us; Turn-On Delay Time: 60us; Case/Package: SC; Category: Hot Swap Controllers; Component Kind: Standard; ComponentLink1Description: https://datasheet.ciiva.com/1962/tps22945-1962436.pdf?src-supplier=Digi-Key; ComponentLink1URL: https://datasheet.ciiva.com/1962/tps22945-1962436.pdf?src-supplier=Digi-Key; ComponentLink2Description: https://datasheet.ciiva.com/15103/getdatasheetpartid-122296-15103873.pdf?src-supplier=Verical; ComponentLink2URL: https://datasheet.ciiva.com/15103/getdatasheetpartid-122296-15103873.pdf?src-supplier=Verical; ComponentLink3Description: https://datasheet.ciiva.com/1512/1840307-1512708.pdf?src-supplier=Element14; ComponentLink3URL: https://datasheet.ciiva.com/1512/1840307-1512708.pdf?src-supplier=Element14; ComponentLink4Description: https://datasheet.ciiva.com/1963/tps22942-1963503.pdf?src-supplier=Digi-Key; ComponentLink4URL: https://datasheet.ciiva.com/1963/tps22942-1963503.pdf?src-supplier=Digi-Key; ComponentLink5Description: https://datasheet.ciiva.com/1963/tps22942-1963502.pdf?src-supplier=Digi-Key; ComponentLink5URL: https://datasheet.ciiva.com/1963/tps22942-1963502.pdf?src-supplier=Digi-Key; ComponentLink6Description: https://datasheet.ciiva.com/3233/tps22945-3233582.pdf?src-supplier=Avnet; ComponentLink6URL: https://datasheet.ciiva.com/3233/tps22945-3233582.pdf?src-supplier=Avnet; ComponentLink7Description: https://datasheet.ciiva.com/26074/tps22945-26074375.pdf?src-supplier=Avnet+Europe; ComponentLink7URL: https://datasheet.ciiva.com/26074/tps22945-26074375.pdf?src-supplier=Avnet+Europe; Lead Free: Lead Free; Library Name: LPEDT_Project.SchLib; Manufacturer Part Number: TPS22942DCKR; Max Operating Temperature: 85°C; Max Supply Voltage: 5.5V; Min Current Limit: 100mA; Min Operating Temperature: -40°C; Min Supply Voltage: 1.62V; Number of Inputs: 1; Number of Outputs: 1; Number of Pins: 5; Package Quantity: 3000; Packaging: Tape and Reel; Pricing 1: 1=1.24000, 10=1.10700, 25=1.05080, 100=0.86310, 250=0.80676, 500=0.71296, 1000=0.61950 (USD); Radiation Hardening: No; REACH SVHC: No SVHC; RoHS: Yes; RoHS Compliant: Yes; Stock 1: 13111; Supplier 1: Digi-Key; Termination: SMD/SMT; Weight: 8.8E-05oz
